# Altium schematic sheet int_dcdc.SchDoc
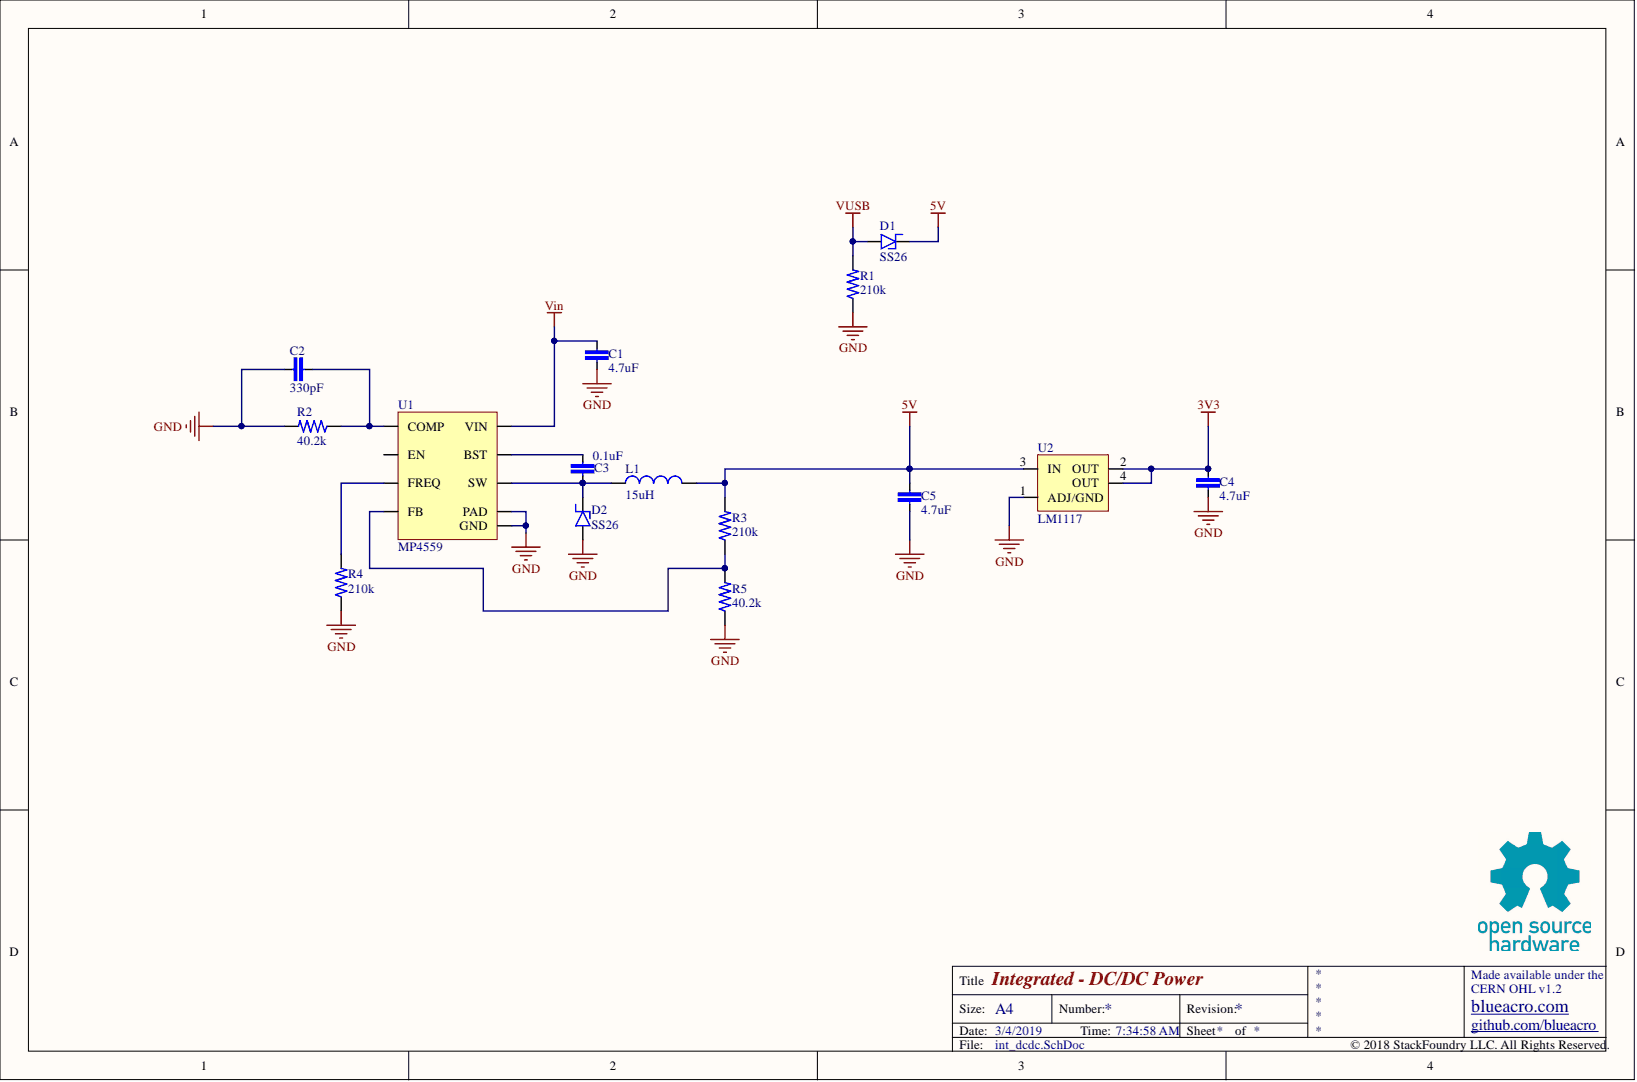
[diagram p0: int_dcdc.SchDoc]

## Parts
C1  4.7uF  [C1210]
C2  330pF  [C1210]
C3  0.1uF  [C0603]
C4  4.7uF  [C1210]
C5  4.7uF  [C1210]
D1  SS26  [SMA]
D2  SS26  [SMA]
L1  15uH  [Inductor_10mm]
R1  210k  [R0603]
R2  40.2k  [R0603]
R3  210k  [R0603]
R4  210k  [R0603]
R5  40.2k  [R0603]
U1  MP4559  [SO8-EP]
U2  LM1117  [SOT223]

## Nets
3V3: C4.2 U2.2(OUT) U2.4(OUT)
5V: C5.2 D1.K L1.2 R3.2 U2.3(IN)
GND: C1.1 C2.2 C4.1 C5.1 D2.A R1.1 R2.2 R4.1 R5.1 U1.5(GND) U1.PAD U2.1(ADJ/GND)
Vin: C1.2 U1.7(VIN)
VUSB: D1.A R1.2
NetC2_1: C2.1 R2.1 U1.3(COMP)
NetC3_1: C3.1 U1.8(BST)
NetC3_2: C3.2 D2.K L1.1 U1.1(SW)
NetR3_1: R3.1 R5.2 U1.4(FB)
NetR4_2: R4.2 U1.6(FREQ)

Not connected: U1 2(EN)

## Library data of the parts
C1-C5 = C-EU: Component Kind: Standard; Library Name: rcl.IntLib
D1 D2 = DIODE_SCHOTTKY: Component Kind: Standard; Library Name: general.IntLib
L1 = L-US: Component Kind: Standard; Library Name: rcl.IntLib
R1-R5 = R-US: Component Kind: Standard; Library Name: rcl.IntLib
U2 = V_REG_LM1117SOT223: Component Kind: Standard; Library Name: general.IntLib
U1 = MP4559: Component Kind: Standard; Library Name: mps.IntLib
